# Altium schematic sheet Power.SchDoc(Power)
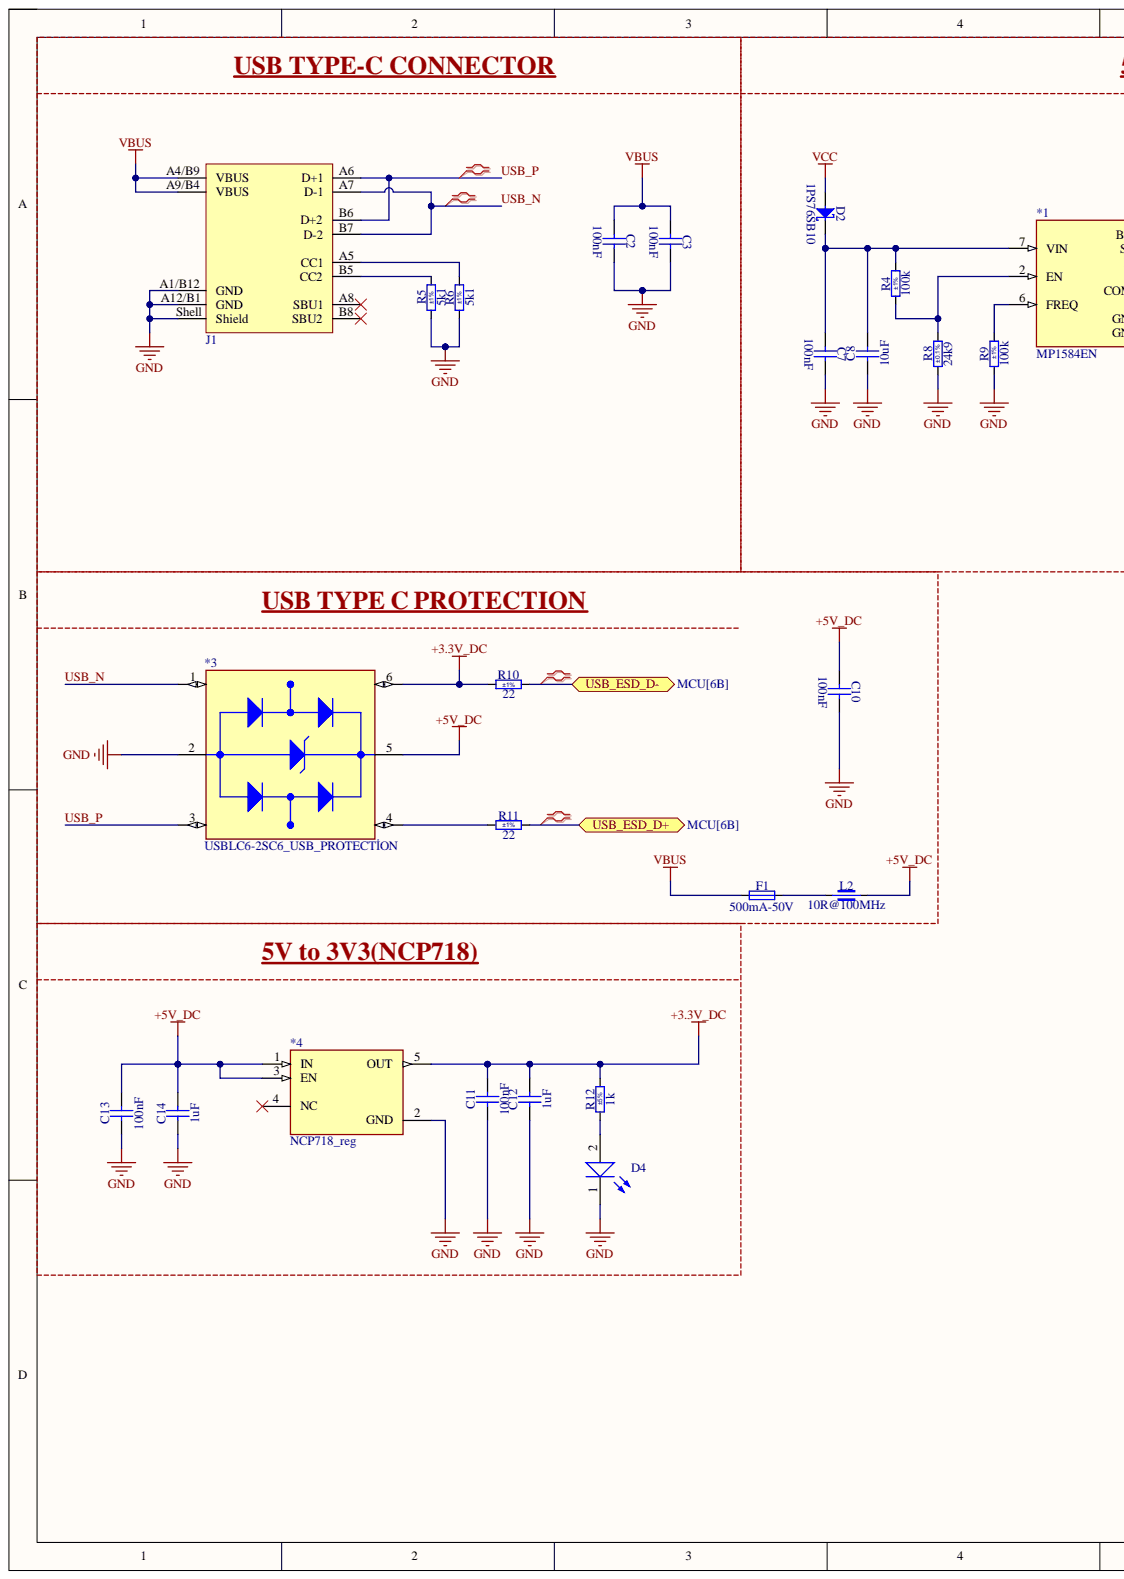
[diagram p0: Power.SchDoc, left]
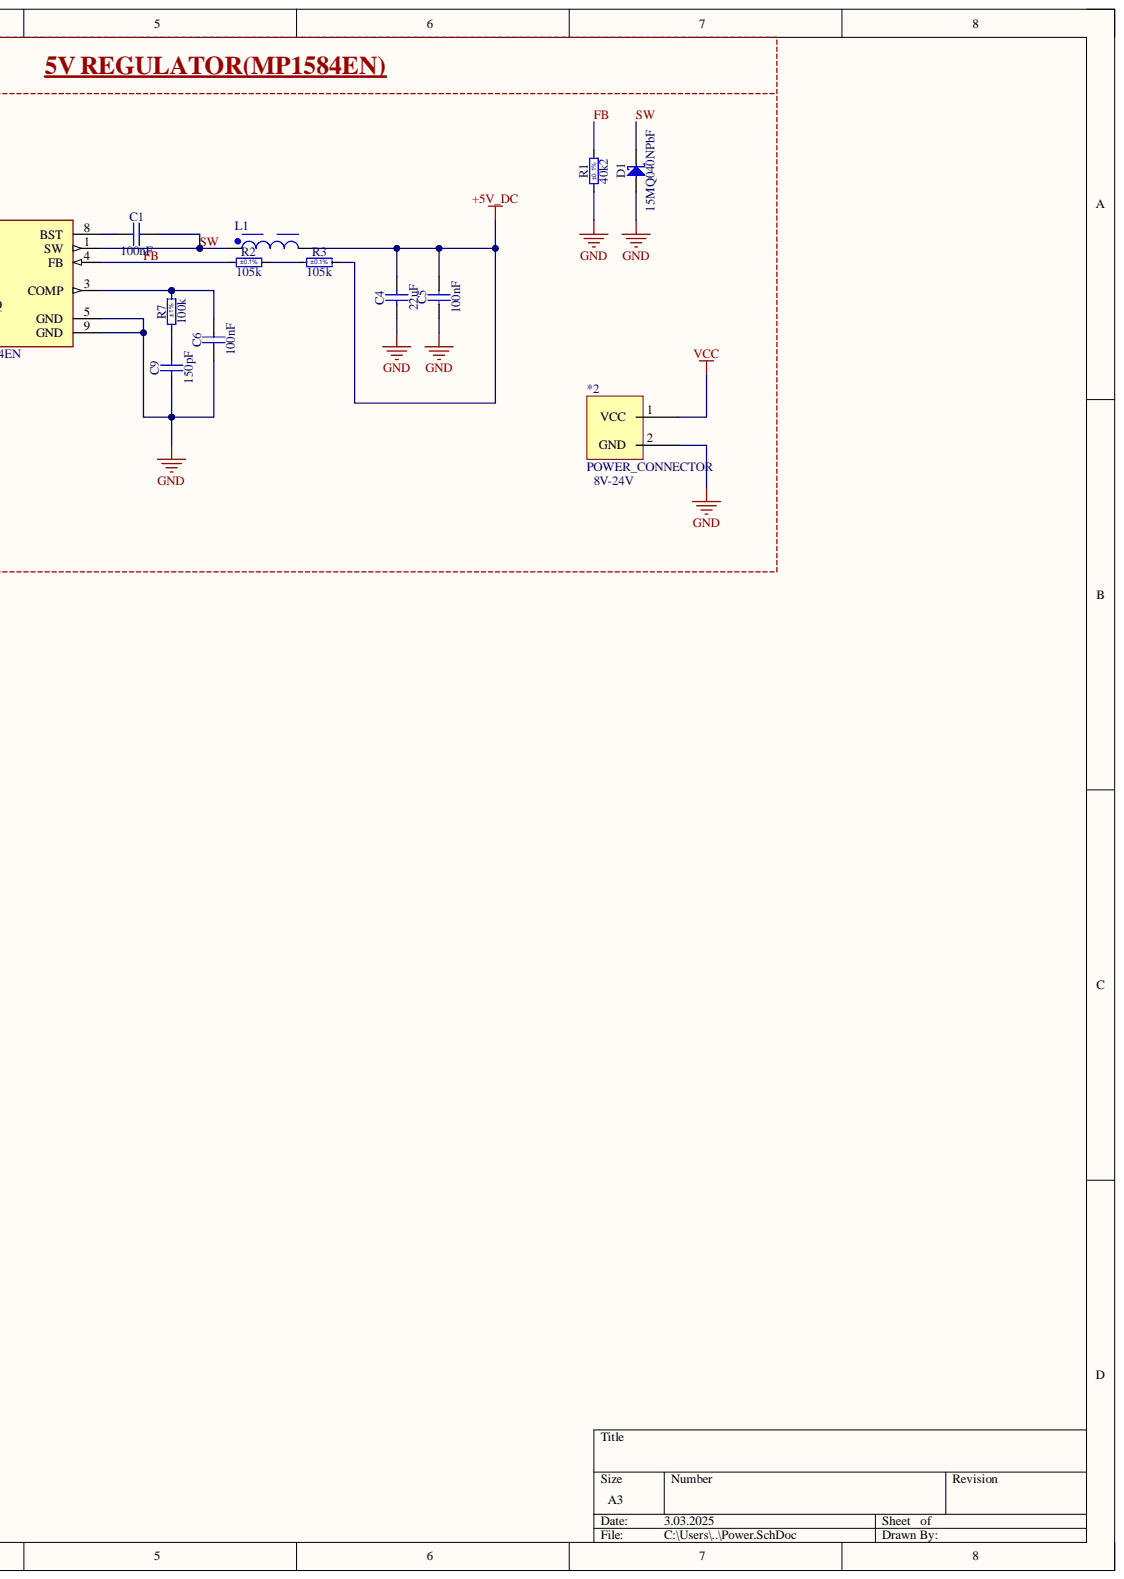
[diagram p1: Power.SchDoc, right]

## Parts
*1  MP1584EN  [MP1584EN]
*2  POWER_CONNECTOR  [POWER_CONNECTOR_lion_batt]
*3  USBLC6-2SC6_USB_PROTECTİON  [USBLC6-2SC6_USB_PROTECTION]
*4  NCP718_reg  [NCP718_reg]
C1  100nF  [CAPC2012X135N]
C2  100nF  [CAPC2012X135N]
C3  100nF  [CAPC2012X135N]
C4  22uF  [CAPC2012X135N]
C5  100nF  [CAPC2012X135N]
C6  100nF  [CAPC2012X135N]
C7  100nF  [CAPC2012X135N]
C8  10uF  [CAPC2012X145N]
C9  150pF  [CAPC2012X135N]
C10  100nF  [CAPC2012X135N]
C11  100nF  [CAPC2012X135N]
C12  1uF  [CAPC2012X140N]
C13  100nF  [CAPC2012X135N]
C14  1uF  [CAPC2012X140N]
D1  15MQ040NPbF  [DIOM5027X244N]
D2  1PS76SB10  [SOD2512X110N]
D4  Sunrise, 3V, 120°  [WL-SWTP_3014_L3W1.4H0.8]  (value not drawn)
F1  500mA-50V  [FUSC_BOURNS_SF-0603F]
J1  629722000214  [629722000214]  (value not drawn)
L1  15uH, 4.2A  [WE-PD_1260]  (value not drawn)
L2  10R@100MHz  [INDC1608X95N]
R1  40k2  Tolerance: ±0.1%  [RESC2012X60N]
R2  105k  Tolerance: ±0.1%  [RESC2012X60N]
R3  105k  Tolerance: ±0.1%  [RESC2012X60N]
R4  100k  Tolerance: ±1%  [RESC2012X60N]
R5  5k1  Tolerance: ±1%  [RESC2012X60N]
R6  5k1  Tolerance: ±1%  [RESC2012X60N]
R7  100k  Tolerance: ±1%  [RESC2012X60N]
R8  24k9  Tolerance: ±0.1%  [RESC2012X60N]
R9  100k  Tolerance: ±1%  [RESC2012X60N]
R10  22  Tolerance: ±1%  [RESC2012X60N]
R11  22  Tolerance: ±1%  [RESC2012X60N]
R12  1k  Tolerance: ±5%  [RESC2012X60N]

## Ports
USB_ESD_D+, USB_ESD_D-

## Nets
+3.3V_DC: *3.6 *4.5(OUT) C11.2 C12.2 R10.1 R12.2
+5V_DC: *3.5 *4.1(IN) *4.3(EN) C4.2 C5.2 C10.1 C13.2 C14.2 L1.2 L2.2 R3.2
GND: *1.5(GND) *1.9(GND) *2.2 *3.2 *4.2(GND) C2.2 C3.2 C4.1 C5.1 C6.1 C7.2 C8.1 C9.1 C10.2 C11.1 C12.1 C13.1 C14.1 D1.2 D4.1 J1.A1/B12(GND) J1.A12/B1(GND) J1.Shell(Shield) R1.1 R5.1 R6.1 R8.1 R9.1
VBUS: C2.1 C3.1 F1.1 J1.A4/B9(VBUS) J1.A9/B4(VBUS)
VCC: *2.1 D2.2
FB: *1.4(FB) R1.2 R2.1
SW: *1.1(SW) C1.2 D1.1 L1.1
USB_N: *3.1 J1.A7(D-1) J1.B7(D-2)
USB_P: *3.3 J1.A6(D+1) J1.B6(D+2)
Net*1_2: *1.2(EN) R4.1 R8.2
Net*1_3: *1.3(COMP) C6.2 R7.2
Net*1_6: *1.6(FREQ) R9.2
Net*1_7: *1.7(VIN) C7.1 C8.2 D2.1 R4.2
Net*1_8: *1.8(BST) C1.1
Net*3_4: *3.4 R11.1
NetC9_2: C9.2 R7.1
NetD4_2: D4.2 R12.1
NetF1_2: F1.2 L2.1
NetJ1_A5: J1.A5(CC1) R6.2
NetJ1_B5: J1.B5(CC2) R5.2
NetR2_2: R2.2 R3.1
NetR10_2: R10.2
NetR11_2: R11.2

Not connected: *4 4(NC); J1 A8(SBU1) B8(SBU2)

## Library data of the parts
*2 = POWER_CONNECTOR_lion_batt: Component Kind: Standard; Library Name: UDEMY_Library.IntLib
C1-C3 C5-C7 C10 C11 C13 = CC0805_100NF_50V_10%_X7R: Non-polarised capacitor; Manufacturer: GENERIC; Manufacturer1 Part Number: C2012X7R1H104K085; Part Description: SMD Multilayer Chip Ceramic Capacitor; Database Table Name: Capacitors SMD; TC: X7R; Tolerance: ±10%; Voltage: 50V; Case: 0805; Component Kind: Standard; Component Type: Standard; CreateDate: 3.12.2007; HelpURL: \\cern.ch\dfs\Applications\Altium\Datasheets\CC0805_X7R_TDK_C.pdf; LatestRevisionDate: 22.04.2015; Library Name: Capacitors.DbLib; Manufacturer1 ComponentHeight: 1mm; Manufacturer1 Example: TDK; Manufacturer Part Number: CC0805_100NF_50V_10%_X7R; Mounted: Yes; Part Number: CC0805_100NF_50V_10%_X7R; Pin Count: 2; Sense: No; SMD: Yes; Socket: No; Status: None; Value: 100nF; Author: CERN DEM JLC
C4 = CC0805_22UF_16V_10%_X5R: Non-polarised capacitor; Manufacturer: GENERIC; Manufacturer1 Part Number: C2012X5R1C226KT; Part Description: SMD Multilayer Chip Ceramic Capacitor; Database Table Name: Capacitors SMD; TC: X5R; Tolerance: ±10%; Voltage: 16V; Case: 0805; Component Kind: Standard; Component Type: Standard; CreateDate: 25.10.2012; HelpURL: \\cern.ch\dfs\Applications\Altium\Datasheets\CC0805_X5R_TDK_C.pdf; LatestRevisionDate: 25.10.2012; Library Name: Capacitors.DbLib; Manufacturer1 ComponentHeight: 1.35mm; Manufacturer1 Example: TDK; Manufacturer Part Number: CC0805_22UF_16V_10%_X5R; Mounted: Yes; Part Number: CC0805_22UF_16V_10%_X5R; Pin Count: 2; Sense: No; SMD: Yes; Socket: No; Status: Preferred; Value: 22uF; Author: CERN DEM JLC
C8 = CC0805_10UF_25V_10%_X5R_YUDEN_TMK212BBJ106KGHT: Non-polarised capacitor; Manufacturer: TAIYO YUDEN; Part Description: SMD Multilayer Chip Ceramic Capacitor (High Dielectric Type) for Automotive / Industrial Applications; Database Table Name: Capacitors SMD; TC: X5R; Tolerance: ±10%; Voltage: 25V; Case: 0805; Component Kind: Standard; Component Type: Standard; ComponentHeight: 1.45mm; CreateDate: 23.01.2018; HelpURL: \\cern.ch\dfs\Applications\Altium\Datasheets\CC0805_TAIYO-YUDEN_TMK212BBJ106KGHT.pdf; LatestRevisionDate: 23.01.2018; Library Name: Capacitors.DbLib; Manufacturer Part Number: TMK212BBJ106KGHT; Mounted: Yes; Part Number: CC0805_10UF_25V_10%_X5R_YUDEN_TMK212BBJ106KGHT; Pin Count: 2; Sense: No; SMD: Yes; Socket: No; Status: Not Recommended; Value: 10uF; Author: CERN DEM JLC
C9 = CC0805_150PF_50V_5%_C0G: Non-polarised capacitor; Manufacturer: GENERIC; Manufacturer1 Part Number: C0805C151J5GACTU; Part Description: SMD Multilayer Chip Ceramic Capacitor; Database Table Name: Capacitors SMD; TC: C0G/NP0; Tolerance: ±5%; Voltage: 50V; Case: 0805; Component Kind: Standard; Component Type: Standard; CreateDate: 3.12.2007; HelpURL: \\cern.ch\dfs\Applications\Altium\Datasheets\CC0805_C0G_KEMET_(Commercial Grade).pdf; LatestRevisionDate: 22.04.2015; Library Name: Capacitors.DbLib; Manufacturer1 ComponentHeight: 0.88mm; Manufacturer1 Example: KEMET; Manufacturer Part Number: CC0805_150PF_50V_5%_C0G; Mounted: Yes; Part Number: CC0805_150PF_50V_5%_C0G; Pin Count: 2; Sense: No; SMD: Yes; Socket: No; Status: Preferred; Value: 150pF; Author: CERN DEM JLC
C12 C14 = CC0805_1UF_35V_20%_X7R: Non-polarised capacitor; Manufacturer: GENERIC; Manufacturer1 Part Number: GMK212B7105MG-T; Part Description: SMD Multilayer Chip Ceramic Capacitor; Database Table Name: Capacitors SMD; TC: X7R; Tolerance: ±20%; Voltage: 35V; Case: 0805; Component Kind: Standard; Component Type: Standard; CreateDate: 22.06.2011; HelpURL: \\cern.ch\dfs\Applications\Altium\Datasheets\CC0805_X7R_TAIYO-YUDEN_HIGH-VALUE.pdf; LatestRevisionDate: 22.06.2011; Library Name: Capacitors.DbLib; Manufacturer1 ComponentHeight: 1.35mm; Manufacturer1 Example: TAIYO YUDEN; Manufacturer Part Number: CC0805_1UF_35V_20%_X7R; Mounted: Yes; Part Number: CC0805_1UF_35V_20%_X7R; Pin Count: 2; Sense: No; SMD: Yes; Socket: No; Status: Not Recommended; Value: 1uF; Author: CERN DEM JLC
D1 = 15MQ040NPbF: Schottky Diode; Manufacturer: VISHAY INTERTECHNOLOGY, INC.; Part Description: Schottky Rectifier; Bonding: No; Database Table Name: Diodes; Power: 3A; PressFit: No; Voltage: 40V; Case: DO-214AC; Component Kind: Standard; Component Type: Standard; ComponentHeight: 2.44mm; CreateDate: 9.03.2009; Device: 15MQ040NPbF; HelpURL: \\cern.ch\dfs\Applications\Altium\Datasheets\15MQ040NPbF.pdf; LatestRevisionDate: 9.06.2022; Library Name: ICs And Semiconductors.DbLib; Manufacturer Part Number: 15MQ040N; Mounted: Yes; PackageDescription: DO-214-AC/SMA  Molded Diode, Body 5.0x2.7mm, IPC Medium Density; Part Number: 15MQ040NPbF; Pin Count: 2; Sense: No; SMD: Yes; Socket: No; Status: Obsolete; Author: CERN DEM JLC
D2 = 1PS76SB10: Schottky Diode; Manufacturer: NXP SEMICONDUCTORS; Part Description: Schottky Barrier Single Diode; Bonding: No; Database Table Name: Diodes; Power: 200mA; PressFit: No; Voltage: 30V; Case: SOD-323; Component Kind: Standard; Component Type: Standard; ComponentHeight: 1.1mm; CreateDate: 6.12.2012; Device: 1PS76SB10; HelpURL: \\cern.ch\dfs\Applications\Altium\Datasheets\1PS76SB10.pdf; LatestRevisionDate: 6.12.2012; Library Name: ICs And Semiconductors.DbLib; Manufacturer Part Number: 1PS76SB10; Mounted: Yes; PackageDescription: Small Outline Diode (SOD-323), 1.70mm W X 1.25mm L X 1.10mm H body,  IPC Medium Density; Part Number: 1PS76SB10; Pin Count: 2; Sense: No; SMD: Yes; Socket: No; Author: CERN DEM JLC
D4 = 158301227: WL-SWTP SMT White Top view PLCC, size 3014, Sunrise, 3V, 120°; Manufacturer: Wurth Elektronik; Case/Size Code: 3014; Chip Technology: InGaN; Color Rendering Index: 85; Correlated Color Temperature: 2700K; Database Table Name: LEDs; Luminous Flux: 8lm; Category: General Lighting; Component Kind: Standard; ComponentLink1Description: Website Link; ComponentLink1URL: https://www.we-online.com/redexpert/article/158301227?ad; ComponentLink2Description: Datasheet Link; ComponentLink2URL: https://www.we-online.com/redexpert/spec/158301227?ad; Emitting Color: Sunrise; Forward Voltage: 3V; Height: 0.8mm; Length: 3mm; Library Name: WE - Optoelectronic Components.DbLib; Manufacturer Part Number: 158301227; Match Code: WL-SWTP; Mount: Surface Mount; Operating Temperature Max: 85°C; Operating Temperature Min: -40°C; Packaging: Tape and Reel; Simulation: 3014_158301227; Viewing Angle: 120°; Width: 1.4mm
F1 = FUS0603_BOURNS-SF-0603F050-2: Fuse; Manufacturer: BOURNS; Part Description: Fast Acting Thin Film Chip Fuse for Overcurrent Protection, SinglFuse™ SF-0603F  Series; Database Table Name: Fuses; PressFit: No; Case: 0603; Component Kind: Standard; Component Type: Standard; ComponentHeight: 0.55mm; CreateDate: 1.02.2017; Family: Fuse; HelpURL: \\cern.ch\dfs\Applications\Altium\Datasheets\FUSC_BOURNS_SF-0603F.pdf; LatestRevisionDate: 1.02.2017; Library Name: Eletro-mechanical.DbLib; Manufacturer Part Number: SF-0603F050-2; Mounted: Yes; PackageDescription: Chip Fuse, 2-Leads, Body 1.6mm x 0.8mm, IPC Medium Density; Part Number: FUS0603_BOURNS-SF-0603F050-2; Pin Count: 2; Sense: No; SMD: Yes; Socket: No; Value: 500mA-50V; Author: CERN DEM JLC
J1 = 629722000214: WR-COM USB 2.0 Type C Receptacle Horizontal SMT; Manufacturer: Wurth Elektronik; Database Table Name: Connectors; Match Code: WR-USB; Number of Ports: 1; Rated Current: 5A; Working Voltage: 20V (DC); Interface Type: Type C; Application: USB 2.0; Category: Input/Output Connectors; Component Kind: Standard; ComponentLink1Description: Website Link; ComponentLink1URL: https://www.we-online.com/redexpert/article/629722000214?ad; ComponentLink2Description: Datasheet Link; ComponentLink2URL: https://www.we-online.com/redexpert/spec/629722000214?ad; Gender: Receptacle; Library Name: WE - Electromechanical Components.DbLib; Manufacturer Part Number: 629722000214; Mount: Surface Mount; Number of Pins: 16; Operating Temperature Max: 85°C; Operating Temperature Min: -40°C; Orientation: Horizontal; Packaging: Tape and Reel
L1 = 744771115: WE-PD SMT Power Inductor, size 1260, 15uH, 4.2A, 28mOhm; Manufacturer: Wurth Elektronik; Case/Size Code: 1260; Database Table Name: Inductors; DC Resistance: 22mOhm; Saturation Current: 4.5A; Self Resonant Frequency: 16.6MHz; Type: Standard; Category: Power Inductors; Component Kind: Standard; ComponentLink1Description: Website Link; ComponentLink1URL: https://www.we-online.com/redexpert/article/744771115?ad; ComponentLink2Description: Datasheet Link; ComponentLink2URL: https://www.we-online.com/redexpert/spec/744771115?ad; DC Resistance Max: 28mOhm; Height: 6mm; Length: 12mm; Library Name: WE - Passive Components.DbLib; Manufacturer Part Number: 744771115; Match Code: WE-PD; Mount: Surface Mount; Operating Temperature Max: 125°C; Operating Temperature Min: -40°C; Packaging: Tape and Reel; Rated Current: 4.2A; Simulation: 1260_744771115_15u; Width: 12mm; Inductance: 15uH
L2 = IND0603_MURATA_BLM18BA100SN1D: Manufacturer: MURATA; Part Description: SMD EMIFIL Suppression Chip Ferrite Bead; Database Table Name: Inductors SMD; Power: 500mA; Resistance: 0.25R; Tolerance: ±25%; Case: 0603; Component Kind: Standard; Component Type: Standard; ComponentHeight: 0.95mm; CreateDate: 13.01.2014; HelpURL: \\cern.ch\dfs\Applications\Altium\Datasheets\IND0603_MURATA_BLM18B.pdf; LatestRevisionDate: 25.10.2018; Library Name: Inductors & Transformers.DbLib; Manufacturer Part Number: BLM18BA100SN1D; Mounted: Yes; Part Number: IND0603_MURATA_BLM18BA100SN1D; Pin Count: 2; Sense: No; SMD: Yes; Socket: No; Value: 10R@100MHz; Author: CERN DEM JLC
R1 = R0805_40K2_0.1%_0.125W_15PPM: Resistor - 0.1%; Manufacturer: GENERIC; Manufacturer1 Part Number: RP73D2A40K2BTG; Part Description: Thin Film Chip Resistor; Database Table Name: Resistors SMD; Power: 0.125W; PressFit: No; TC: ±15ppm/°C; Case: 0805; Component Kind: Standard; Component Type: Standard; CreateDate: 5.03.2015; HelpURL: \\cern.ch\dfs\Applications\Altium\Datasheets\R0805_TYCO_RP73.pdf; LatestRevisionDate: 28.06.2022; Library Name: Resistors.DbLib; Manufacturer1 ComponentHeight: 0.65mm; Manufacturer1 Example: TYCO ELECTRONICS; Manufacturer Part Number: R0805_40K2_0.1%_0.125W_15PPM; Mounted: Yes; Part Number: R0805_40K2_0.1%_0.125W_15PPM; Pin Count: 2; Sense: No; SMD: Yes; Socket: No; Status: Not Recommended; Value: 40k2; Author: CERN DEM JLC
R2 R3 = R0805_105K_0.1%_0.1W_10PPM: Resistor - 0.1%; Manufacturer: GENERIC; Manufacturer1 Part Number: RN73C2A105KBTG; Part Description: Thin Film Chip Resistor; Database Table Name: Resistors SMD; Power: 0.1W; PressFit: No; TC: ±10ppm; Case: 0805; Component Kind: Standard; Component Type: Standard; CreateDate: 3.12.2007; HelpURL: \\cern.ch\dfs\Applications\Altium\Datasheets\R0805_Tyco_RN73.pdf; LatestRevisionDate: 13.03.2008; Library Name: Resistors.DbLib; Manufacturer1 ComponentHeight: 0.6mm; Manufacturer1 Example: TYCO HOLSWORTHY; Manufacturer Part Number: R0805_105K_0.1%_0.1W_10PPM; Mounted: Yes; Part Number: R0805_105K_0.1%_0.1W_10PPM; Pin Count: 2; Sense: No; SMD: Yes; Socket: No; Status: Not Recommended; Value: 105k; Author: CERN DEM JLC
R4 R7 R9 = R0805_100K_1%_0.125W_100PPM: Resistor - 1%; Manufacturer: GENERIC; Manufacturer1 Part Number: NRC10F1003TRF; Part Description: General Purpose Thick Film Chip Resistor; Database Table Name: Resistors SMD; Power: 0.125W; PressFit: No; TC: ±100ppm/°C; Case: 0805; Component Kind: Standard; Component Type: Standard; CreateDate: 3.12.2007; HelpURL: \\cern.ch\dfs\Applications\Altium\Datasheets\R0805_NIC_NRC.pdf; LatestRevisionDate: 13.03.2008; Library Name: Resistors.DbLib; Manufacturer1 ComponentHeight: 0.6mm; Manufacturer1 Example: NIC COMPONENT; Manufacturer Part Number: R0805_100K_1%_0.125W_100PPM; Mounted: Yes; Part Number: R0805_100K_1%_0.125W_100PPM; Pin Count: 2; Sense: No; SMD: Yes; Socket: No; Status: Preferred; Value: 100k; Author: CERN DEM JLC
R5 R6 = R0805_5K1_1%_0.125W_100PPM: Resistor - 1%; Manufacturer: GENERIC; Manufacturer1 Part Number: NRC10F5101TRF; Part Description: General Purpose Thick Film Chip Resistor; Database Table Name: Resistors SMD; Power: 0.125W; PressFit: No; TC: ±100ppm/°C; Case: 0805; Component Kind: Standard; Component Type: Standard; CreateDate: 3.12.2007; HelpURL: \\cern.ch\dfs\Applications\Altium\Datasheets\R0805_NIC_NRC.pdf; LatestRevisionDate: 13.03.2008; Library Name: Resistors.DbLib; Manufacturer1 ComponentHeight: 0.6mm; Manufacturer1 Example: NIC COMPONENT; Manufacturer Part Number: R0805_5K1_1%_0.125W_100PPM; Mounted: Yes; Part Number: R0805_5K1_1%_0.125W_100PPM; Pin Count: 2; Sense: No; SMD: Yes; Socket: No; Status: Preferred; Value: 5k1; Author: CERN DEM JLC
R8 = R0805_24K9_0.1%_0.1W_10PPM: Resistor - 0.1%; Manufacturer: GENERIC; Manufacturer1 Part Number: RN73C2A24K9BTG; Part Description: Thin Film Chip Resistor; Database Table Name: Resistors SMD; Power: 0.1W; PressFit: No; TC: ±10ppm; Case: 0805; Component Kind: Standard; Component Type: Standard; CreateDate: 3.12.2007; HelpURL: \\cern.ch\dfs\Applications\Altium\Datasheets\R0805_Tyco_RN73.pdf; LatestRevisionDate: 13.03.2008; Library Name: Resistors.DbLib; Manufacturer1 ComponentHeight: 0.6mm; Manufacturer1 Example: TYCO HOLSWORTHY; Manufacturer Part Number: R0805_24K9_0.1%_0.1W_10PPM; Mounted: Yes; Part Number: R0805_24K9_0.1%_0.1W_10PPM; Pin Count: 2; Sense: No; SMD: Yes; Socket: No; Status: Not Recommended; Value: 24k9; Author: CERN DEM JLC
R10 R11 = R0805_22R_1%_0.125W_100PPM: Resistor - 1%; Manufacturer: GENERIC; Manufacturer1 Part Number: NRC10F22R0TRF; Part Description: General Purpose Thick Film Chip Resistor; Database Table Name: Resistors SMD; Power: 0.125W; PressFit: No; TC: ±100ppm/°C; Case: 0805; Component Kind: Standard; Component Type: Standard; CreateDate: 3.12.2007; HelpURL: \\cern.ch\dfs\Applications\Altium\Datasheets\R0805_NIC_NRC.pdf; LatestRevisionDate: 13.03.2008; Library Name: Resistors.DbLib; Manufacturer1 ComponentHeight: 0.6mm; Manufacturer1 Example: NIC COMPONENT; Manufacturer Part Number: R0805_22R_1%_0.125W_100PPM; Mounted: Yes; Part Number: R0805_22R_1%_0.125W_100PPM; Pin Count: 2; Sense: No; SMD: Yes; Socket: No; Status: Preferred; Value: 22; Author: CERN DEM JLC
R12 = R0805_1K_0.1%_0.125W_25PPM: Resistor - 0.1%; Manufacturer: GENERIC; Manufacturer1 Part Number: ERA6AEB102V; Part Description: Thin Film Chip Resistor; Database Table Name: Resistors SMD; Power: 0.125W; PressFit: No; TC: ±25ppm; Case: 0805; Component Kind: Standard; Component Type: Standard; CreateDate: 18.10.2010; HelpURL: \\cern.ch\dfs\Applications\Altium\Datasheets\R0805_PANASONIC_ERA.pdf; LatestRevisionDate: 18.10.2010; Library Name: Resistors.DbLib; Manufacturer1 ComponentHeight: 0.6mm; Manufacturer1 Example: PANASONIC; Manufacturer Part Number: R0805_1K_0.1%_0.125W_25PPM; Mounted: Yes; Part Number: R0805_1K_0.1%_0.125W_25PPM; Pin Count: 2; Sense: No; SMD: Yes; Socket: No; Status: Not Recommended; Value: 1k; Author: CERN DEM JLC
*1 = MP1584EN: Component Kind: Standard; Library Name: UDEMY_Library.IntLib
*3 = USBLC6-2SC6_USB_PROTECTİON: Component Kind: Standard; Library Name: UDEMY_Library.IntLib
*4 = NCP718_reg: Component Kind: Standard; Library Name: UDEMY_Library.IntLib
